# Altium schematic sheet USB.SchDoc(USB)
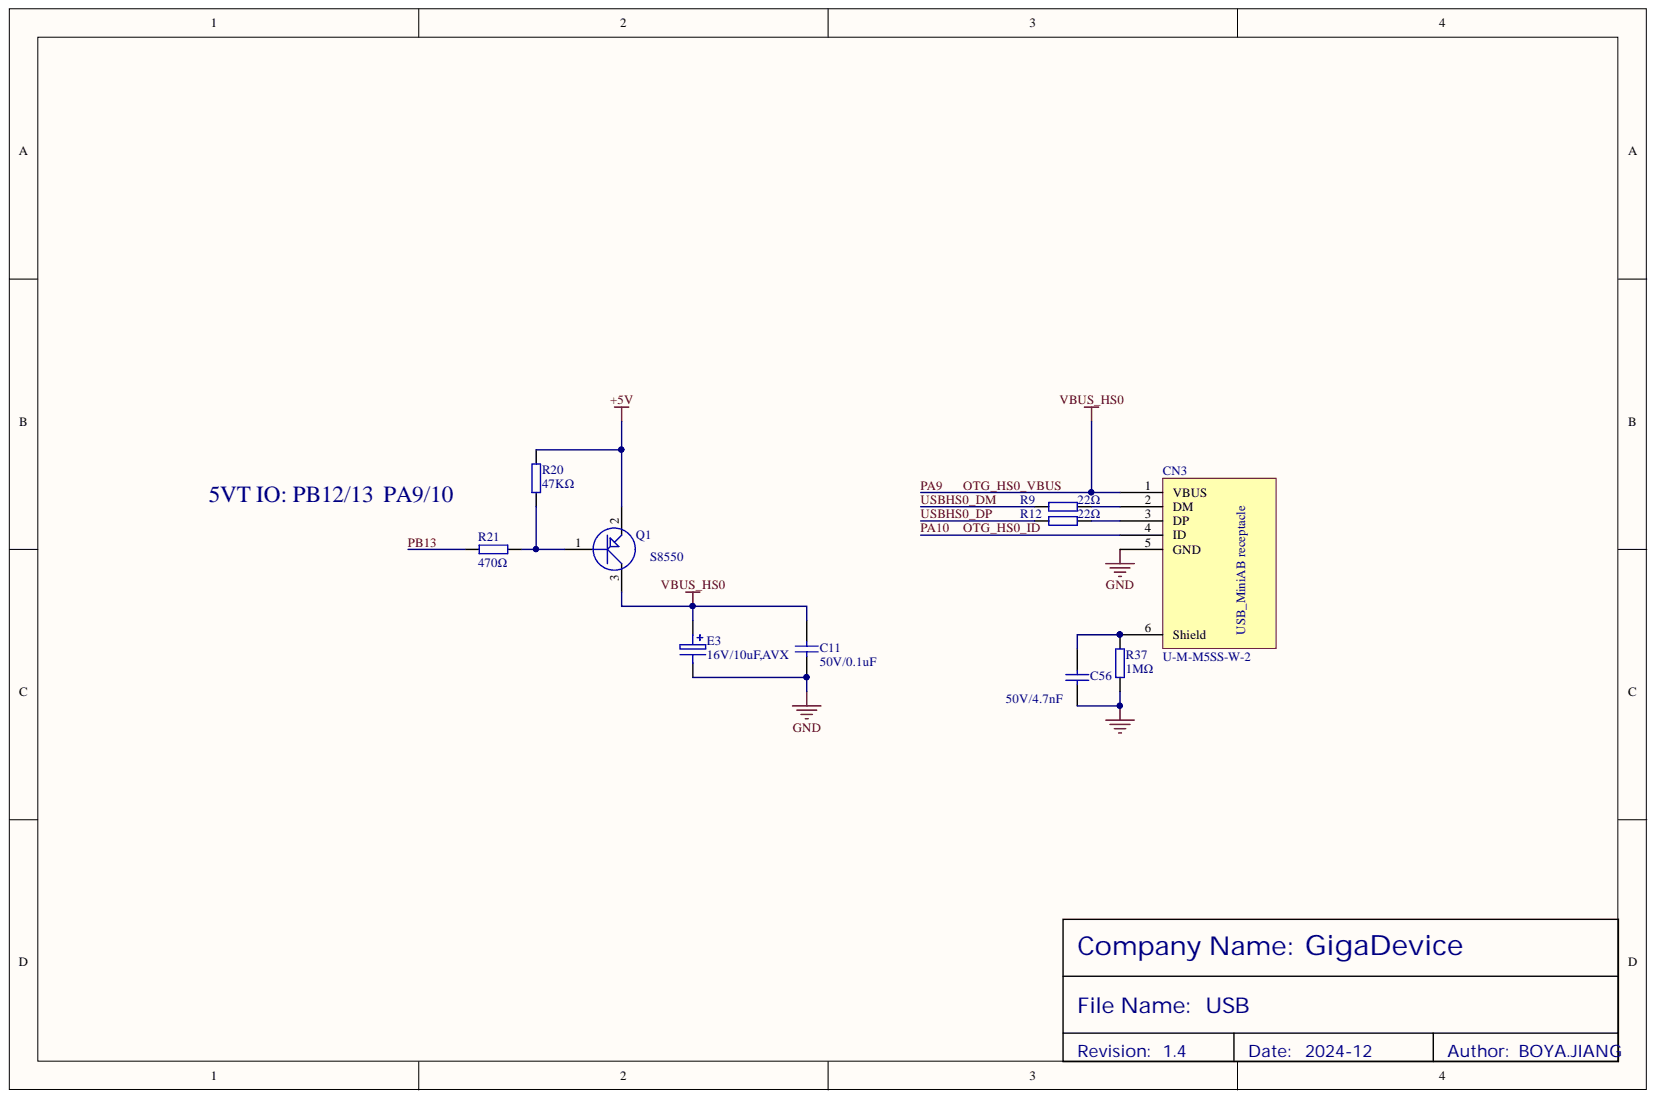
[diagram p0: USB.SchDoc]

## Parts
C11  50V/0.1uF  [0603-C]
C56  50V/4.7nF  [0603-C]
CN3  U-M-M5SS-W-2  [USB_MINI]
E3  16V/10uF,AVX  [SM/C-A-3216]
Q1  S8550  [SOT-23]
R9  22Ω  [0603-R]
R12  22Ω  [0603-R]
R20  47KΩ  [0603-R]
R21  470Ω  [0603-R]
R37  1MΩ  [0603-R]

## Nets
+5V: Q1.2 R20.2
GND: C11.2 C56.1 CN3.5(GND) E3.2 R37.1
OTG_HS0_ID: CN3.4(ID)
OTG_HS0_VBUS: C11.1 CN3.1(VBUS) E3.1 Q1.3
PB13: R21.1
USBHS0_DM: R9.1
USBHS0_DP: R12.1
NetC56_2: C56.2 CN3.6(Shield) R37.2
NetCN3_2: CN3.2(DM) R9.2
NetCN3_3: CN3.3(DP) R12.2
NetQ1_1: Q1.1 R20.1 R21.2

## Library data of the parts
C11 = 50V/0.01uF: 表贴电容; Component Kind: Standard; Library Name: KLTC-电容.SchLib
C56 = 50V/4700pF: 表贴电容; Component Kind: Standard; Library Name: KLTC-电容.SchLib
CN3 = Mini_USB: 表贴miniusb座; Library Name: GDM3203ZE-EVAL.IntLib; Component Kind: Standard
E3 = 16V/10uF,AVX: 表贴钽电容; Component Kind: Standard; Library Name: KLTC-电容.SchLib
Q1 = 9012(MMBT9012LT): 表贴三极管; Component Kind: Standard; Library Name: KLTC-二三极管.SchLib
R9 R12 R20 R21 = 1KΩ: 表贴电阻; Component Kind: Standard; Library Name: KLTC-电阻.SchLib
R37 = 1MΩ: 表贴电阻; Component Kind: Standard; Library Name: KLTC-电阻.SchLib
